# Altium schematic sheet Sync_ISP.SchDoc
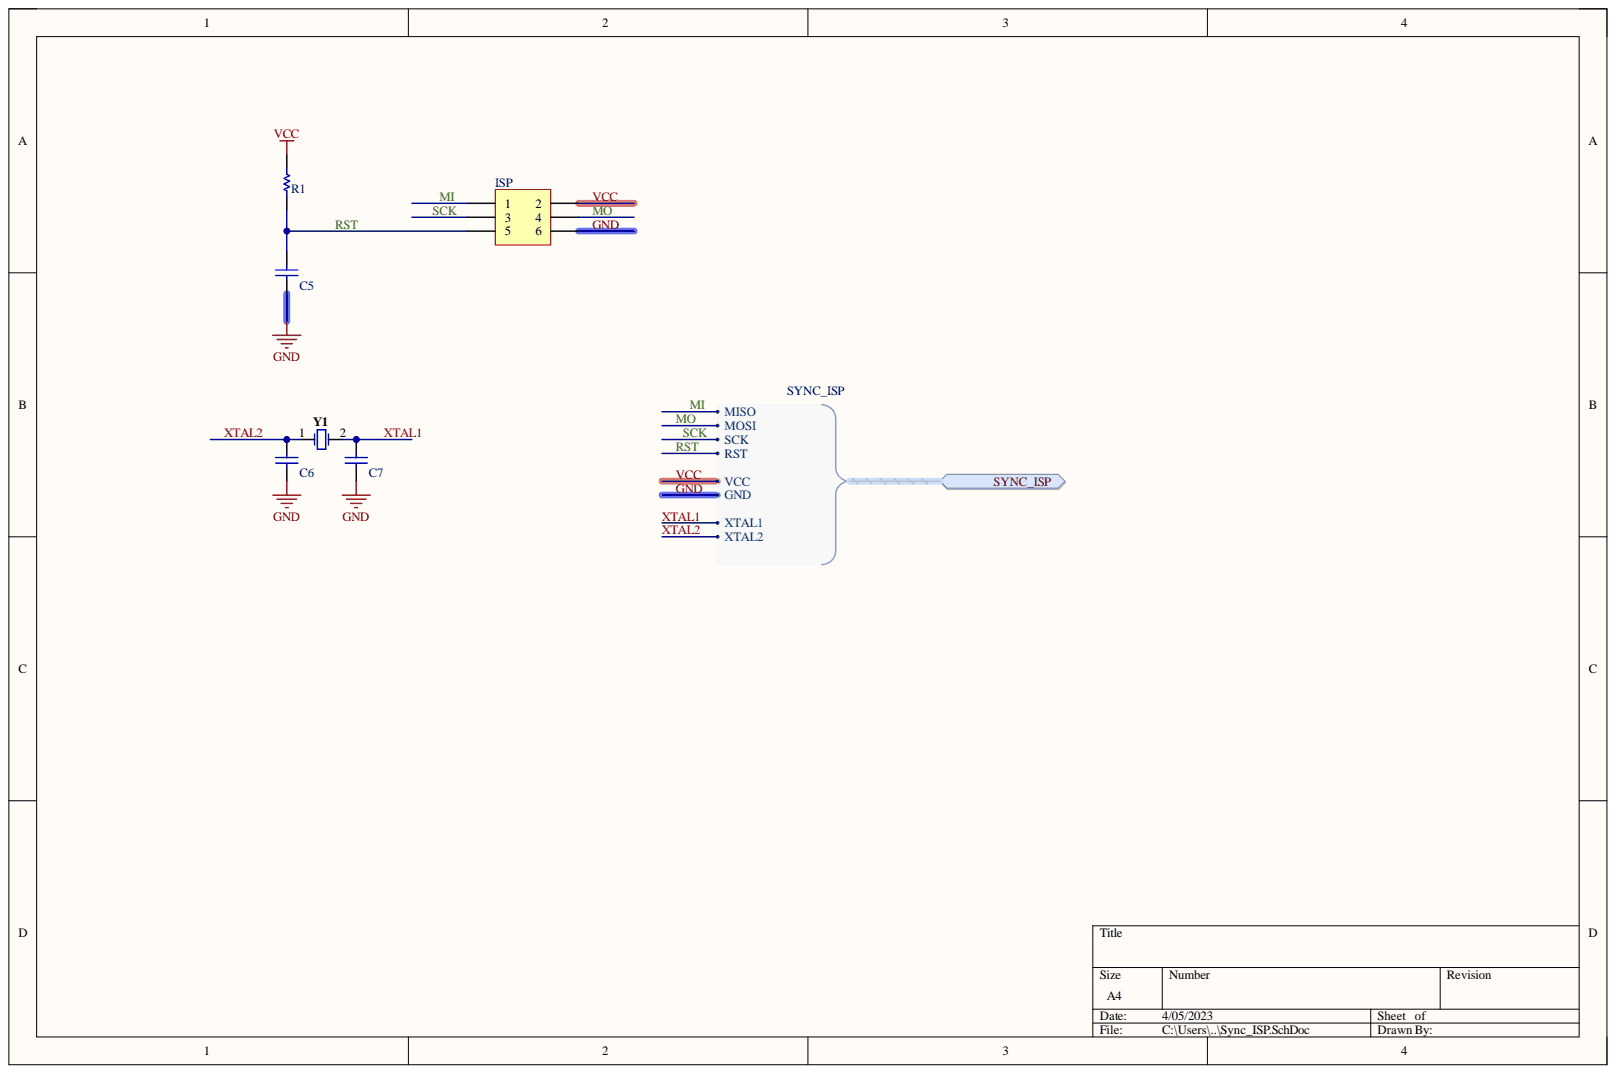
[diagram p0: Sync_ISP.SchDoc]

## Parts
C5  CAP 270pF 100V 0805(2012)  [CAPC0805(2012)100_N]  (value not drawn)
C6  CAP 270pF 100V 0805(2012)  [CAPC0805(2012)100_N]  (value not drawn)
C7  CAP 270pF 100V 0805(2012)  [CAPC0805(2012)100_N]  (value not drawn)
ISP  Header 3X2  [HDR2X3]  (value not drawn)
R1  2K49 1% 0805(2012)  [RESC2013X50X30LL20T20]  (value not drawn)
Y1  HC-49US 16.000MHZ  [JPY-HC-49US]  (value not drawn)

## Ports
SYNC_ISP, SYNC_ISP.GND, SYNC_ISP.MISO, SYNC_ISP.MOSI, SYNC_ISP.RST, SYNC_ISP.SCK, SYNC_ISP.VCC, SYNC_ISP.XTAL1, SYNC_ISP.XTAL2

## Nets
GND: C5.1 C6.1 C7.1 ISP.6 ; port SYNC_ISP
MI: ISP.1 ; port SYNC_ISP
MO: ISP.4 ; port SYNC_ISP
RST: C5.2 ISP.5 R1.2 ; port SYNC_ISP
SCK: ISP.3 ; port SYNC_ISP
VCC: ISP.2 R1.1 ; port SYNC_ISP
XTAL1: C7.2 Y1.2 ; port SYNC_ISP
XTAL2: C6.2 Y1.1 ; port SYNC_ISP

## Library data of the parts
C5 = CAP: CAP 270pF 100V ±1% 0805 (2012 Metric) Thickness 1mm SMD; Case-EIA: 0805; Case-Metric: 2012; Tolerance: Â±1%; Value: 10n; Component Kind: Standard; Library Name: RF_Monitor_1.SCHLIB; Max Thickness: 1 mm; Rated Voltage: 100 V; Technology: SMT
C6 C7 = CAP: CAP 270pF 100V ±1% 0805 (2012 Metric) Thickness 1mm SMD; Case-EIA: 0805; Case-Metric: 2012; Tolerance: Â±1%; Value: 100n; Component Kind: Standard; Library Name: RF_Monitor_1.SCHLIB; Max Thickness: 1 mm; Rated Voltage: 100 V; Technology: SMT
ISP = Header 3X2: Header, 3-Pin, Dual row; Component Kind: Standard; LatestRevisionDate: 17-Jul-2002; LatestRevisionNote: Re-released for DXP Platform.; Library Name: RF_Monitor.SCHLIB; Signal Integrity: Connector; Publisher: Altium Limited
R1 = RES: 2K49 0.125W 1% 0805 (2012 Metric)  SMD; Case-EIA: 0805; Case-Metric: 2012; Value: 4k7; Component Kind: Standard; Library Name: RF_Monitor_1.SCHLIB; Power: 0.125 W; Technology: SMT; Tolerance: 1 %
Y1 = XTAL-1-2-2: Crystal, 16 MHz, +/- 30 ppm, 18 pF, -20 to 70 degC, 2-Pin THD; Manufacturer: Jing peng yuan; Component Kind: Standard; Datasheet URL: https://statics3.seeedstudio.com/images/opl/datasheet/306010014.pdf; Datasheet Version: 42125.0; Library Name: RF_Monitor.SCHLIB; Package Description: 2-Pin Throug Hole Device, Body 11.05 x 4.65 mm, Pitch 4.9 mm; Package Reference: HC-49US
